# Altium schematic sheet DMotor_Schematic_page3.SchDoc
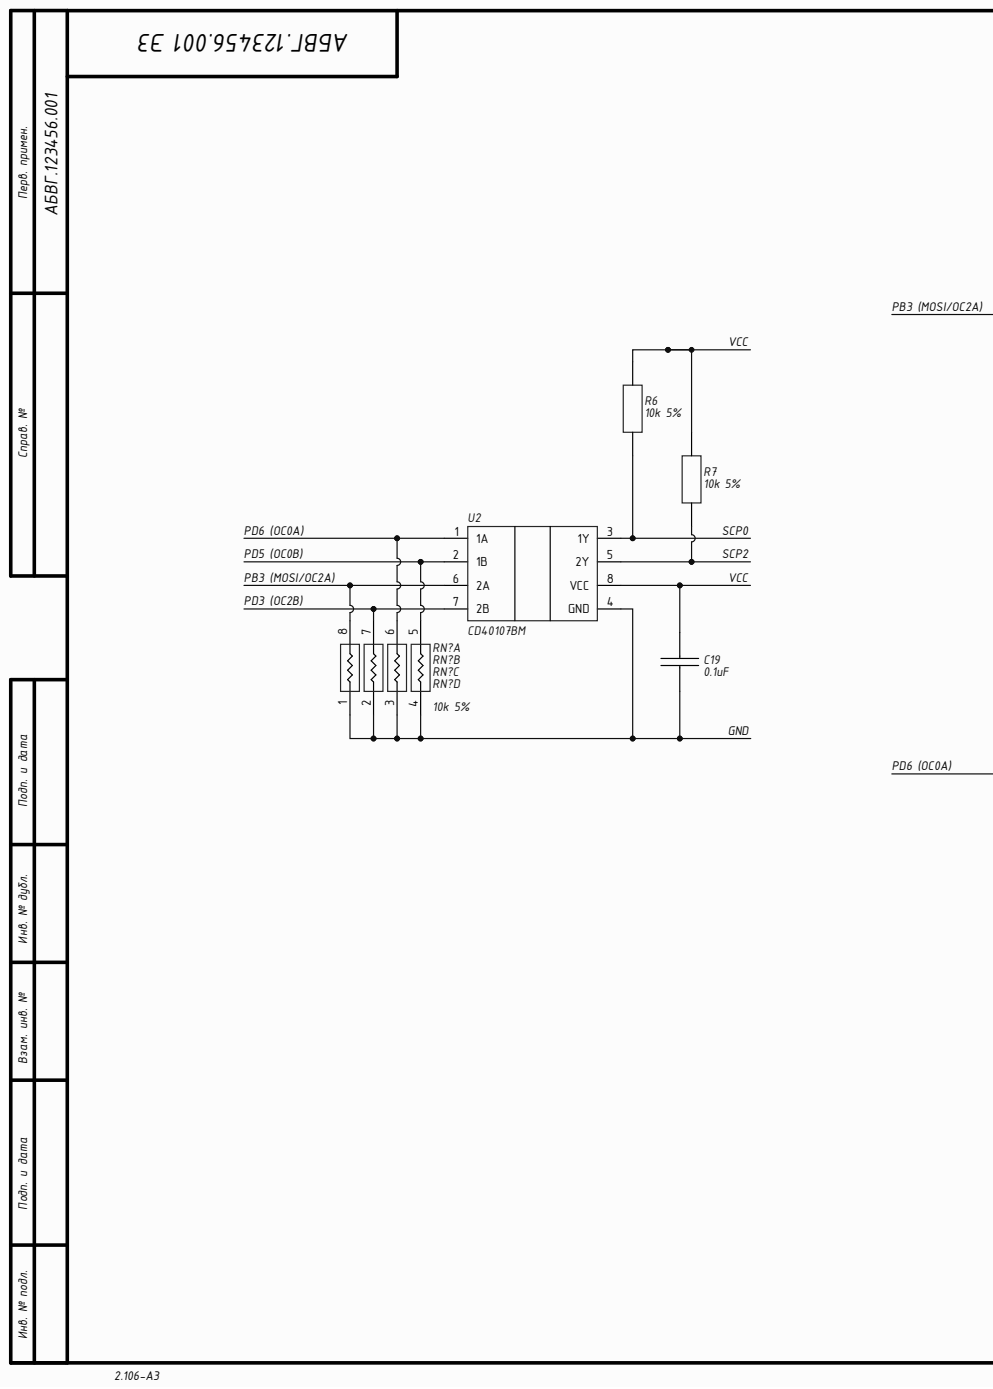
[diagram p0: DMotor_Schematic_page3.SchDoc, left]
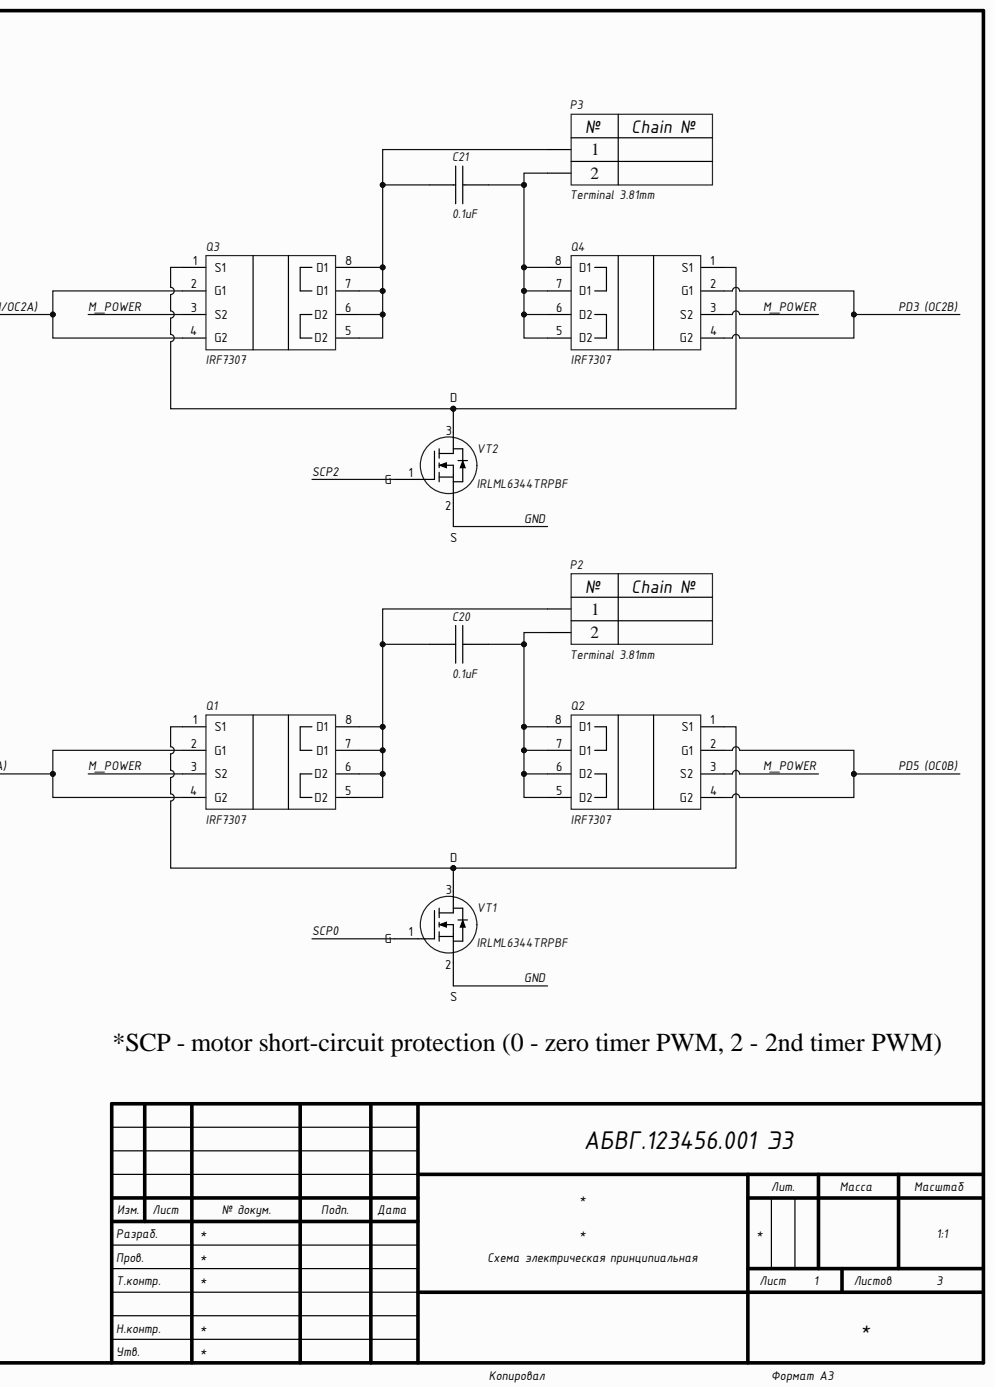
[diagram p1: DMotor_Schematic_page3.SchDoc, right]

## Parts
C19  0.1uF  [C0603]
C20  0.1uF  [C0603]
C21  0.1uF  [C0603]
P2  Terminal 3.81mm  [Terminal 2x 3.81mm]
P3  Terminal 3.81mm  [Terminal 2x 3.81mm]
Q1  IRF7307  [SOIC-8]
Q2  IRF7307  [SOIC-8]
Q3  IRF7307  [SOIC-8]
Q4  IRF7307  [SOIC-8]
R6  10k 5%  [R0603]
R7  10k 5%  [R0603]
U2  CD40107BM  [SOIC-8]
VT1  IRLML6344TRPBF  [SOT-23-3]
VT2  IRLML6344TRPBF  [SOT-23-3]

## Nets
GND: VT1.2 VT2.2
M_POWER: Q1.3(S2) Q2.3(S2) Q3.3(S2) Q4.3(S2)
PB3 (MOSI/OC2A): Q3.2(G1) Q3.4(G2) U2.6(2A)
PD3 (OC2B): Q4.2(G1) Q4.4(G2) U2.7(2B)
PD5 (OC0B): Q2.2(G1) Q2.4(G2) U2.2(1B)
PD6 (OC0A): Q1.2(G1) Q1.4(G2) U2.1(1A)
SCP0: R6.2 U2.3(1Y) VT1.1
SCP2: R7.2 U2.5(2Y) VT2.1
VCC: C19.1 R6.1 R7.1 U2.8(VCC)
NetC19_2: C19.2 U2.4(GND)
NetC20_1: C20.1 P2.2 Q2.5(D2) Q2.6(D2) Q2.7(D1) Q2.8(D1)
NetC20_2: C20.2 P2.1 Q1.5(D2) Q1.6(D2) Q1.7(D1) Q1.8(D1)
NetC21_1: C21.1 P3.2 Q4.5(D2) Q4.6(D2) Q4.7(D1) Q4.8(D1)
NetC21_2: C21.2 P3.1 Q3.5(D2) Q3.6(D2) Q3.7(D1) Q3.8(D1)
NetQ1_1: Q1.1(S1) Q2.1(S1) VT1.3
NetQ3_1: Q3.1(S1) Q4.1(S1) VT2.3

## Library data of the parts
C19-C21 = Capasitor: Component Kind: Standard; Library Name: Board_lib.IntLib; Value: 0.1uF
Q1-Q4 = IRF7307: Mosfet Array N and P-Channel 20V 5.2A, 4.3A 2W Surface Mount 8-SO; Manufacturer: Infineon Technologies; Case: SOIC-8; Component Kind: Standard; HelpURL: https://www.digikey.com/product-detail/en/infineon-technologies/IRF7307TRPBF/IRF7307PBFCT-ND/812597; Library Name: Board_lib.IntLib; Model: IRF7307
R6 R7 = Resistor: Component Kind: Standard; Library Name: Board_lib.IntLib; Value: 10k 5%
U2 = CD40107BM: NAND Gate IC 2 Channel Open Drain 8-SOIC; Manufacturer: Texas Instruments; Case: SOIC-8; Component Kind: Standard; HelpURL: https://www.chipdip.by/product/cd40107bm; Library Name: Board_lib.IntLib; Model: CD40107BM
VT1 VT2 = IRLML6344TRPBF: N-Channel 30V 5A (Ta) 1.3W (Ta) Surface Mount Micro3™/SOT-23; Manufacturer: Infineon Technologies; Case: SOT-23-3; Component Kind: Standard; HelpURL: https://www.digikey.com/product-detail/en/infineon-technologies/IRLML6344TRPBF/IRLML6344TRPBFCT-ND/2538168; Library Name: Board_lib.IntLib; Model: IRLML6344TRPBF
P2 P3 = Terminal 3.81mm: Component Kind: Standard; Library Name: Board_lib.IntLib
